# Altium schematic sheet DIP2350.SchDoc
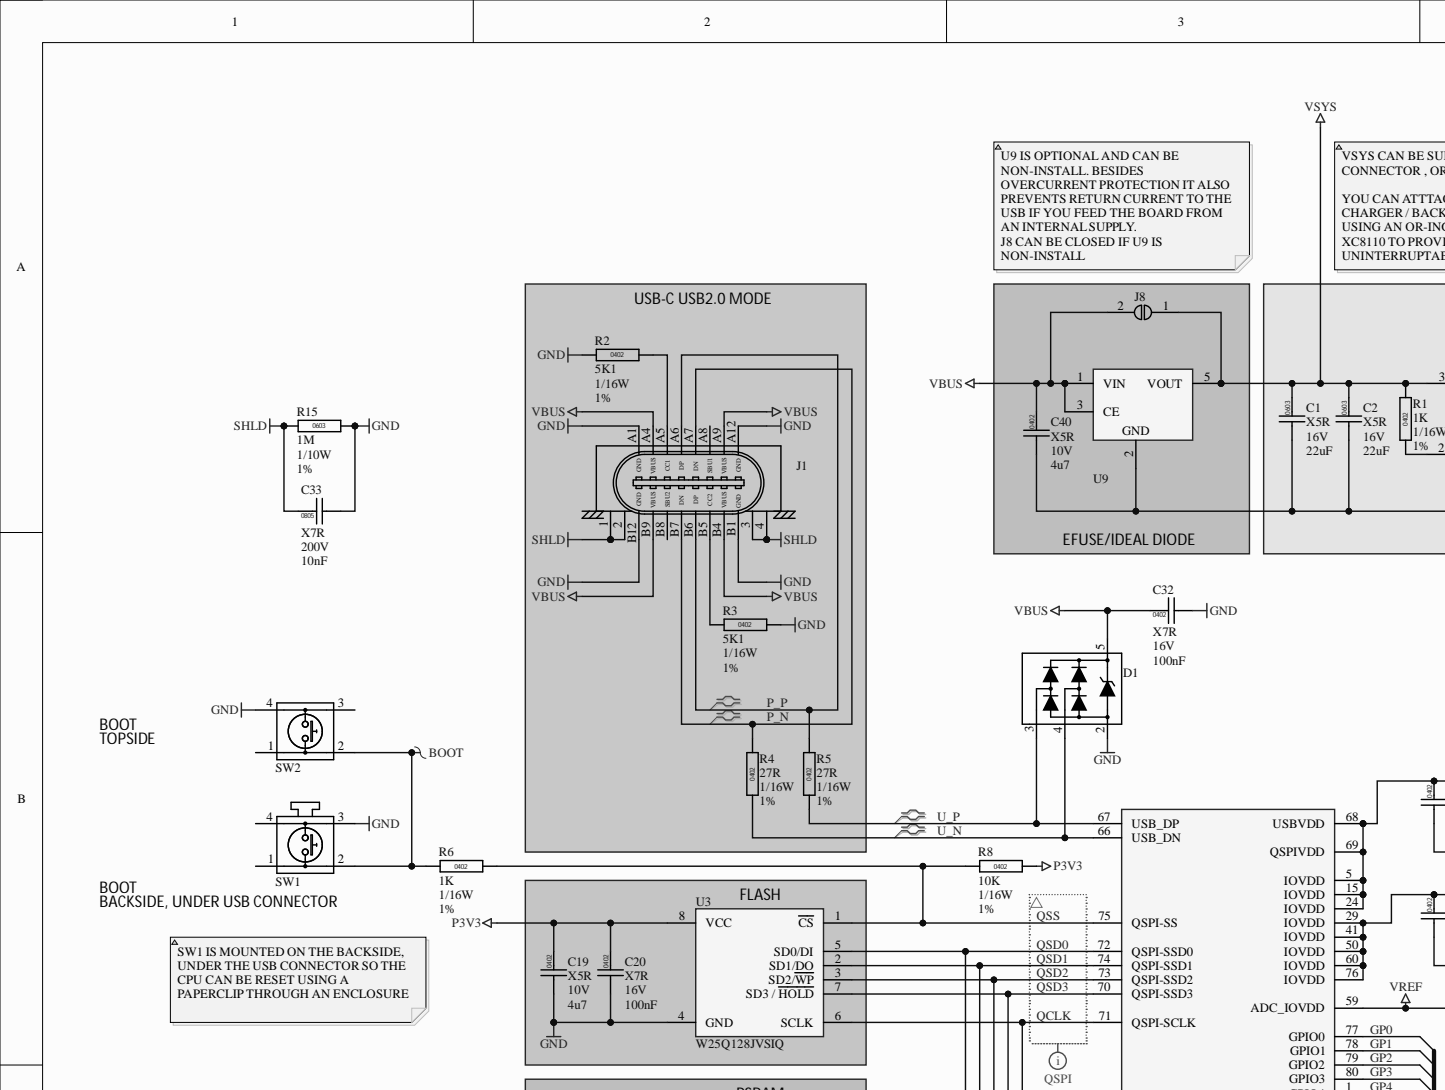
[diagram p0: DIP2350.SchDoc, top left]
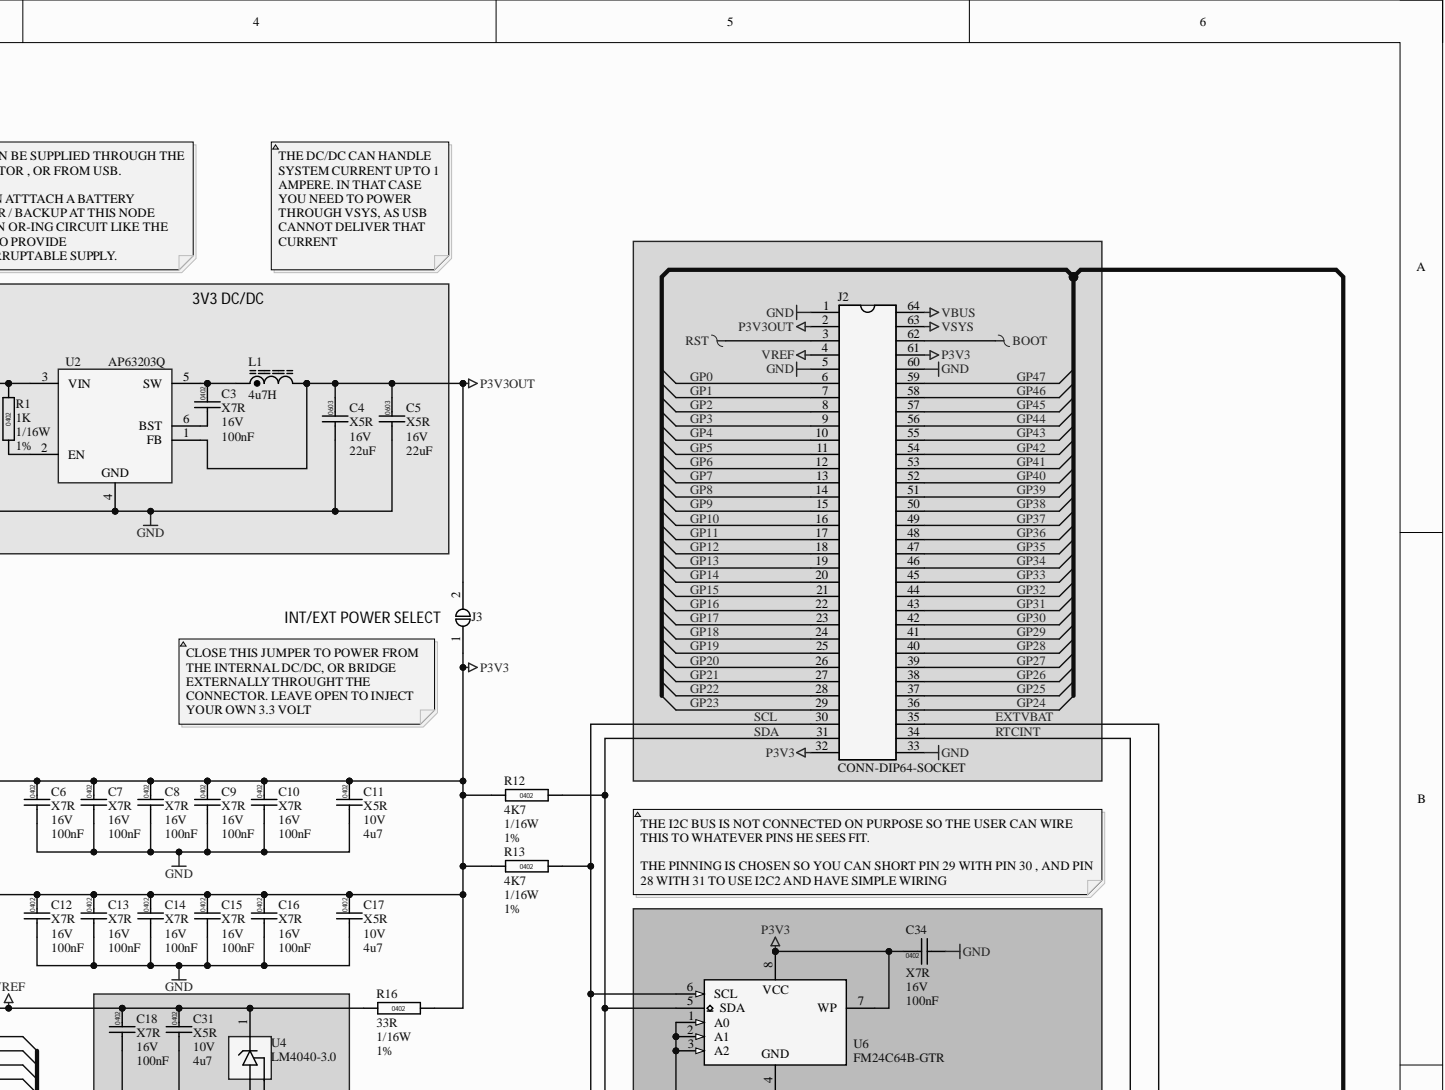
[diagram p1: DIP2350.SchDoc, top right]
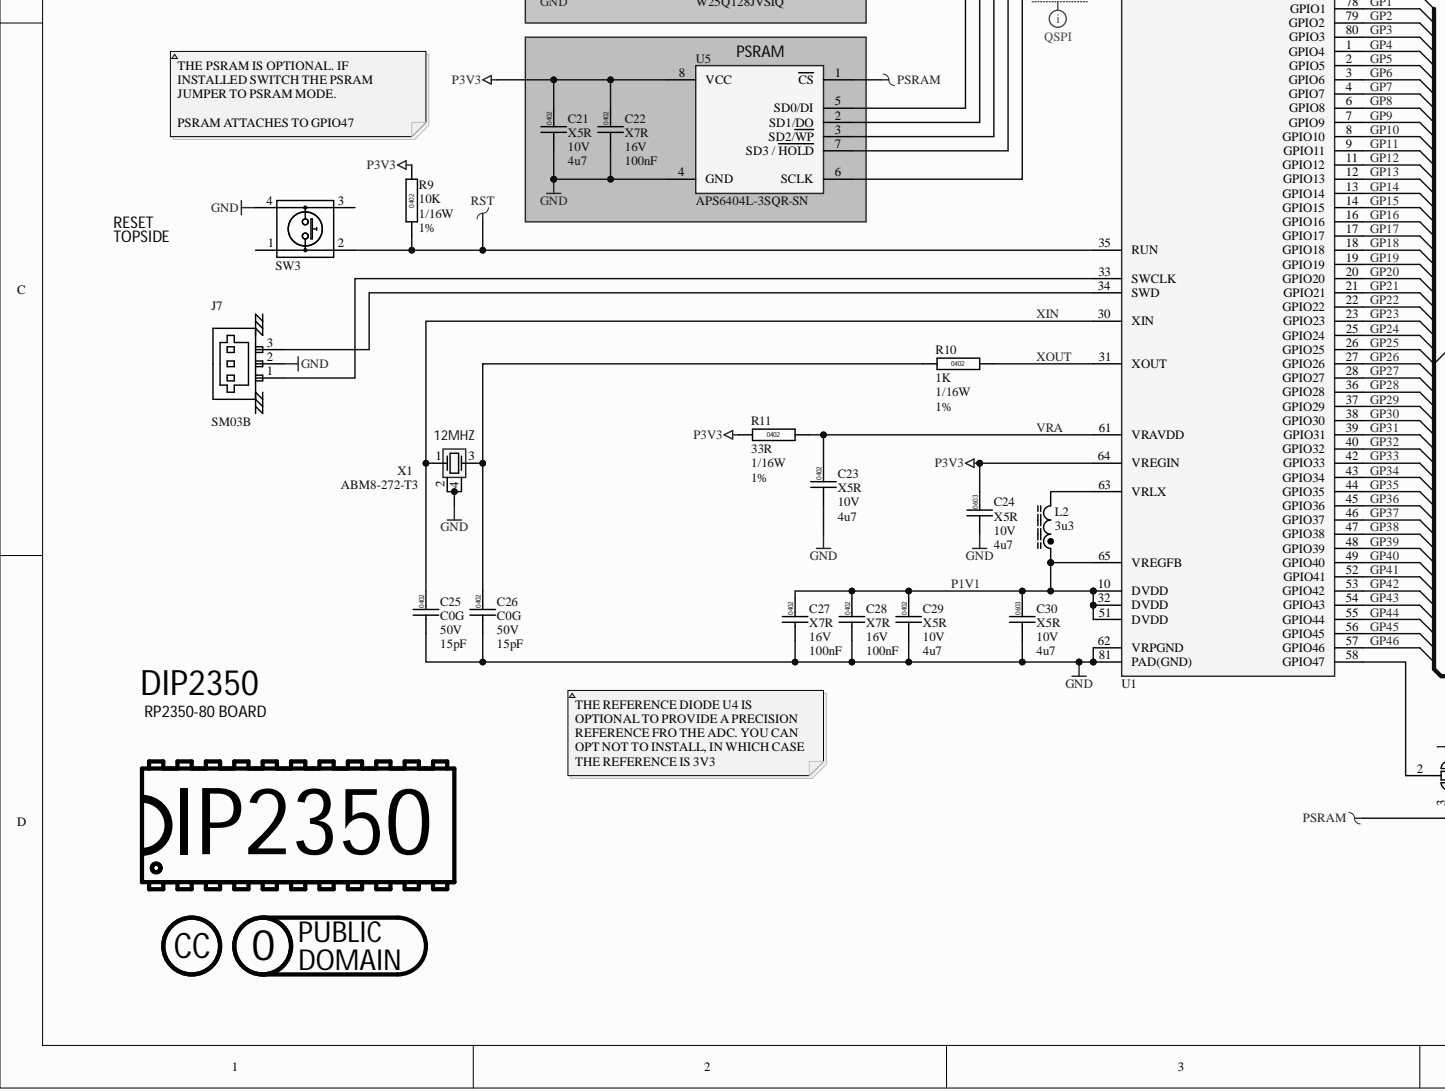
[diagram p2: DIP2350.SchDoc, bottom left]
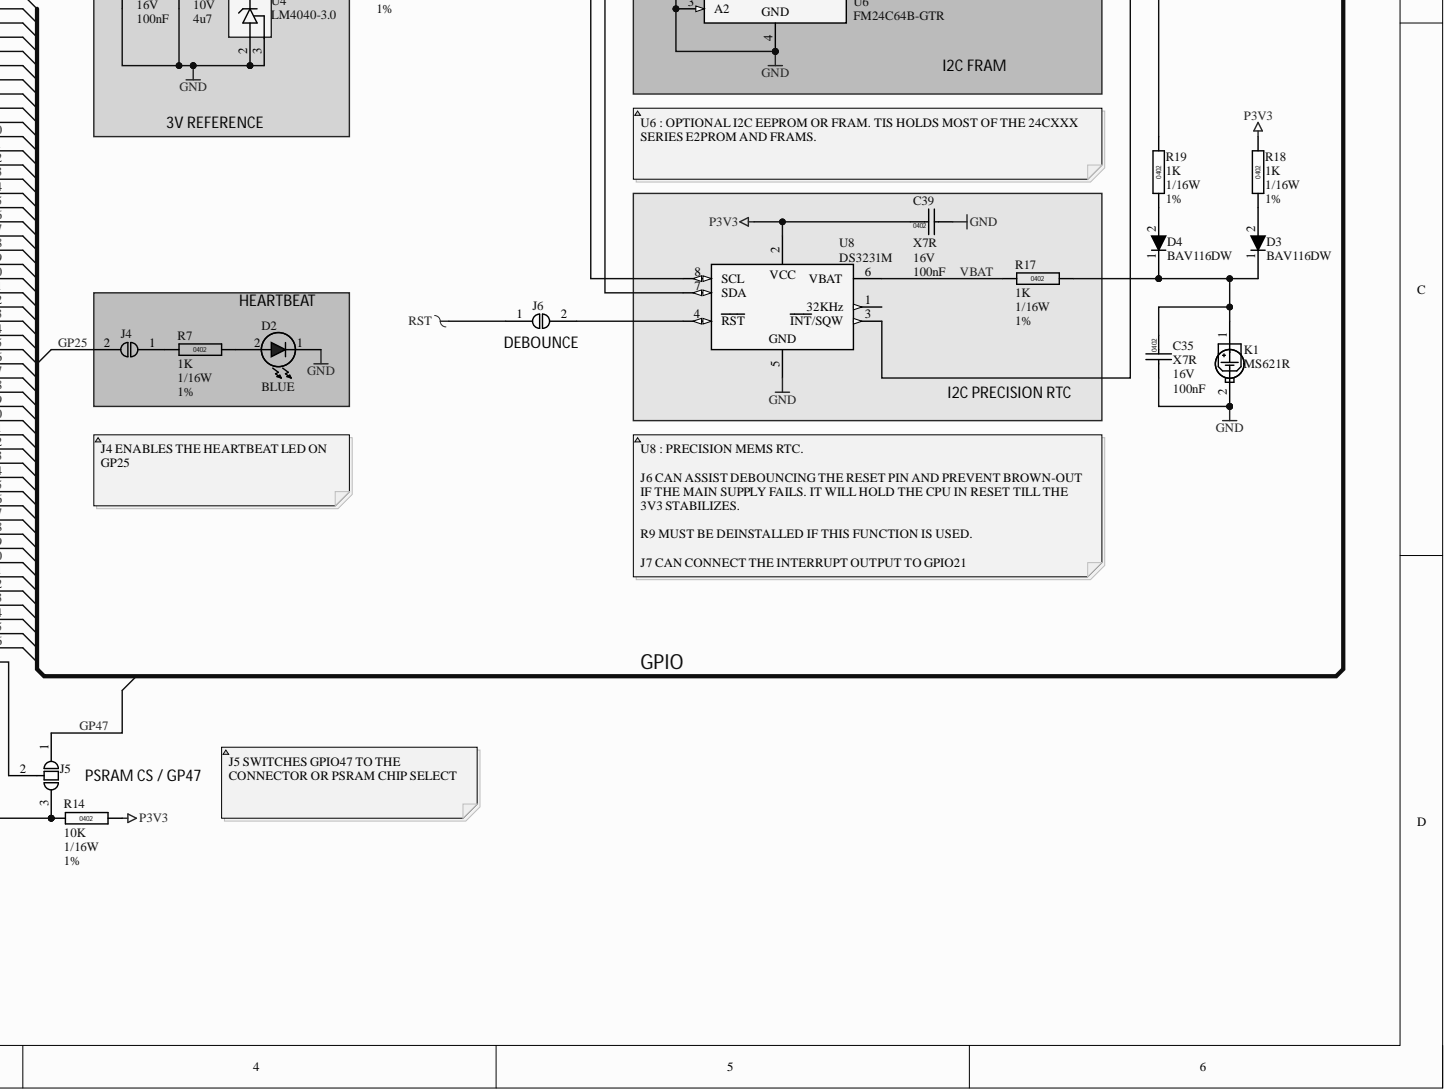
[diagram p3: DIP2350.SchDoc, bottom right]

## Parts
C1  Capacitance: 22uF  Dielectric: X5R  Package / Case: 0603  Voltage: 16V  [CAPC0603]  (hidden comment: CAP0603)
C2  Capacitance: 22uF  Dielectric: X5R  Package / Case: 0603  Voltage: 16V  [CAPC0603]  (hidden comment: CAP0603)
C3  Capacitance: 100nF  Dielectric: X7R  Package / Case: 0402  Voltage: 16V  [CAPC0402]  (hidden comment: CAP0402)
C4  Capacitance: 22uF  Dielectric: X5R  Package / Case: 0603  Voltage: 16V  [CAPC0603]  (hidden comment: CAP0603)
C5  Capacitance: 22uF  Dielectric: X5R  Package / Case: 0603  Voltage: 16V  [CAPC0603]  (hidden comment: CAP0603)
C6  Capacitance: 100nF  Dielectric: X7R  Package / Case: 0402  Voltage: 16V  [CAPC0402]  (hidden comment: CAP0402)
C7  Capacitance: 100nF  Dielectric: X7R  Package / Case: 0402  Voltage: 16V  [CAPC0402]  (hidden comment: CAP0402)
C8  Capacitance: 100nF  Dielectric: X7R  Package / Case: 0402  Voltage: 16V  [CAPC0402]  (hidden comment: CAP0402)
C9  Capacitance: 100nF  Dielectric: X7R  Package / Case: 0402  Voltage: 16V  [CAPC0402]  (hidden comment: CAP0402)
C10  Capacitance: 100nF  Dielectric: X7R  Package / Case: 0402  Voltage: 16V  [CAPC0402]  (hidden comment: CAP0402)
C11  Capacitance: 4u7  Dielectric: X5R  Voltage: 10V  [CAPC0402]  (hidden comment: CAP0402)
C12  Capacitance: 100nF  Dielectric: X7R  Package / Case: 0402  Voltage: 16V  [CAPC0402]  (hidden comment: CAP0402)
C13  Capacitance: 100nF  Dielectric: X7R  Package / Case: 0402  Voltage: 16V  [CAPC0402]  (hidden comment: CAP0402)
C14  Capacitance: 100nF  Dielectric: X7R  Package / Case: 0402  Voltage: 16V  [CAPC0402]  (hidden comment: CAP0402)
C15  Capacitance: 100nF  Dielectric: X7R  Package / Case: 0402  Voltage: 16V  [CAPC0402]  (hidden comment: CAP0402)
C16  Capacitance: 100nF  Dielectric: X7R  Package / Case: 0402  Voltage: 16V  [CAPC0402]  (hidden comment: CAP0402)
C17  Capacitance: 4u7  Dielectric: X5R  Voltage: 10V  [CAPC0402]  (hidden comment: CAP0402)
C18  Capacitance: 100nF  Dielectric: X7R  Package / Case: 0402  Voltage: 16V  [CAPC0402]  (hidden comment: CAP0402)
C19  Capacitance: 4u7  Dielectric: X5R  Voltage: 10V  [CAPC0402]  (hidden comment: CAP0402)
C20  Capacitance: 100nF  Dielectric: X7R  Package / Case: 0402  Voltage: 16V  [CAPC0402]  (hidden comment: CAP0402)
C21  Capacitance: 4u7  Dielectric: X5R  Voltage: 10V  [CAPC0402]  (hidden comment: CAP0402)
C22  Capacitance: 100nF  Dielectric: X7R  Package / Case: 0402  Voltage: 16V  [CAPC0402]  (hidden comment: CAP0402)
C23  Capacitance: 4u7  Dielectric: X5R  Voltage: 10V  [CAPC0402]  (hidden comment: CAP0402)
C24  Capacitance: 4u7  Dielectric: X5R  Package / Case: 0603  Voltage: 10V  [CAPC0603]  (hidden comment: CAP0603)
C25  Capacitance: 15pF  Dielectric: C0G  Package / Case: 0402  Voltage: 50V  [CAPC0402]  (hidden comment: CAP0603)
C26  Capacitance: 15pF  Dielectric: C0G  Package / Case: 0402  Voltage: 50V  [CAPC0402]  (hidden comment: CAP0603)
C27  Capacitance: 100nF  Dielectric: X7R  Package / Case: 0402  Voltage: 16V  [CAPC0402]  (hidden comment: CAP0402)
C28  Capacitance: 100nF  Dielectric: X7R  Package / Case: 0402  Voltage: 16V  [CAPC0402]  (hidden comment: CAP0402)
C29  Capacitance: 4u7  Dielectric: X5R  Voltage: 10V  [CAPC0402]  (hidden comment: CAP0402)
C30  Capacitance: 4u7  Dielectric: X5R  Package / Case: 0603  Voltage: 10V  [CAPC0603]  (hidden comment: CAP0603)
C31  Capacitance: 4u7  Dielectric: X5R  Voltage: 10V  [CAPC0402]  (hidden comment: CAP0402)
C32  Dielectric: X7R  Package / Case: 0402  Voltage: 16V  [CAPC0402]  (hidden comment: CAP0402)
C33  Capacitance: 10nF  Dielectric: X7R  Voltage: 200V  [CAPC0805]  (hidden comment: CAP0805)
C34  Capacitance: 100nF  Dielectric: X7R  Package / Case: 0402  Voltage: 16V  [CAPC0402]  (hidden comment: CAP0402)
C35  Capacitance: 100nF  Dielectric: X7R  Package / Case: 0402  Voltage: 16V  [CAPC0402]  (hidden comment: CAP0402)
C39  Dielectric: X7R  Package / Case: 0402  Voltage: 16V  [CAPC0402]  (hidden comment: CAP0402)
C40  Dielectric: X5R  Voltage: 10V  [CAPC0402]  (hidden comment: CAP0402)
D1  TVS-WURTH-824011  [SOT23-5]  (value not drawn)
D2  BLUE  [LED-0603-BLUE]
D3  BAV116DW  [SOD323]
D4  BAV116DW  [SOD323]
J1  CONN-USBC-USB2-HOR  [CONN-GCT-USB4085]  (value not drawn)
J2  CONN-DIP64-SOCKET  [CONN-DIP64-SOCKET]  (no BOM)
J3  SOLDERPAD-2  [SOLDERPAD-2]  (value not drawn)  (no BOM)
J4  SOLDERPAD-2  [SOLDERPAD-2]  (value not drawn)  (no BOM)
J5  SOLDERPAD-3  [SOLDERPAD-3]  (value not drawn)  (no BOM)
J6  SOLDERPAD-2  [SOLDERPAD-2]  (value not drawn)  (no BOM)
J7  SM03B  [CONN-JST-SM03B-SRSS-TB]
J8  SOLDERPAD-2  [SOLDERPAD-2]  (value not drawn)  (no BOM)
K1  MS621R  [BAT-SEIKO-MS621R]
L1  value 4u7H  [IND-ABRACON-ASPI-3012S-3R9N-T]  (hidden comment: 4.7uH)
L2  value 3u3  [IND-ABRACON-B201610S3R3-101-T]  (hidden comment: AOTA-B201610S3R3-101-T)
LOGO1  LOGO-LICENSE-CC0-PUBLIC  [LOGO-LICENSE-CC0-PUBLIC]  (value not drawn)  (no BOM)
LOGO2  LOGO-LICENSE-CC  [LOGO-LICENSE-CC]  (value not drawn)  (no BOM)
R1  value 1K  Power: 1/16W  Tolerance: 1%  [RESC0402]  (hidden comment: RESC0402)
R2  value 5K1  Power: 1/16W  [RESC0402]  (hidden comment: RESC0402)
R3  value 5K1  Power: 1/16W  Tolerance: 1%  [RESC0402]  (hidden comment: RESC0402)
R4  value 27R  Power: 1/16W  Tolerance: 1%  [RESC0402]  (hidden comment: RESC0402)
R5  value 27R  Power: 1/16W  Tolerance: 1%  [RESC0402]  (hidden comment: RESC0402)
R6  value 1K  Power: 1/16W  Tolerance: 1%  [RESC0402]  (hidden comment: RESC0402)
R7  value 1K  Power: 1/16W  Tolerance: 1%  [RESC0402]  (hidden comment: RESC0402)
R8  value 10K  Power: 1/16W  Tolerance: 1%  [RESC0402]  (hidden comment: RESC0402)
R9  value 10K  Power: 1/16W  Tolerance: 1%  [RESC0402]  (hidden comment: RESC0402)
R10  value 1K  Power: 1/16W  Tolerance: 1%  [RESC0402]  (hidden comment: RESC0402)
R11  value 33R  Power: 1/16W  Tolerance: 1%  [RESC0402]  (hidden comment: RESC0402)
R12  value 4K7  Power: 1/16W  [RESC0402]  (hidden comment: RESC0402)
R13  value 4K7  Power: 1/16W  Tolerance: 1%  [RESC0402]  (hidden comment: RESC0402)
R14  value 10K  Power: 1/16W  Tolerance: 1%  [RESC0402]  (hidden comment: RESC0402)
R15  value 1M  Power: 1/10W  [RESC0603]  (hidden comment: RESC0603)
R16  value 33R  Power: 1/16W  Tolerance: 1%  [RESC0402]  (hidden comment: RESC0402)
R17  value 1K  Power: 1/16W  Tolerance: 1%  [RESC0402]  (hidden comment: RESC0402)
R18  value 1K  Power: 1/16W  Tolerance: 1%  [RESC0402]  (hidden comment: RESC0402)
R19  value 1K  Power: 1/16W  Tolerance: 1%  [RESC0402]  (hidden comment: RESC0402)
SW1  SWITCH-E-SWITCH-TL1016  [SWITCH-ESWITCH-TL1016]  (value not drawn)
SW2  SWITCH-PANASONIC-EVP-AA202K  [SWITCH-PANASONIC-EVP-AA202K]  (value not drawn)
SW3  SWITCH-PANASONIC-EVP-AA202K  [SWITCH-PANASONIC-EVP-AA202K]  (value not drawn)
U1  IC-RP2350-DIP64  [QFN40P1000X1000X90_HS-81N]  (value not drawn)
U2  AP63203Q  [SOT23-6]
U3  W25Q128JVSIQ  [SO127P790-8N]
U4  LM4040-3.0  [SOT23]
U5  APS6404L-3SQR-SN  [SO127P600-8N]
U6  FM24C64B-GTR  [SO127P600-8N]
U8  DS3231M  [SO127P600-8N]
U9  XC8110  [SOT23-5]  (value not drawn)
X1  ABM8-272-T3  [XTAL-ABRACON-ABM8-272-T3]

## Nets
BOOT: J2.62 R6.1 SW1.2 SW2.2
GND: C1.2 C2.2 C4.2 C5.2 C6.2 C7.2 C8.2 C9.2 C10.2 C11.2 C12.2 C13.2 C14.2 C15.2 C16.2 C17.2 C18.2 C19.2 C20.2 C21.2 C22.2 C23.2 C24.2 C25.2 C26.2 C27.2 C28.2 C29.2 C30.2 C31.2 C32.2 C33.2 C34.2 C35.2 C39.2 C40.2 D1.2 D2.1 J1.A1(GND) J1.A12(GND) J1.B1(GND) J1.B12(GND) J2.1 J2.5 J2.33 J2.60 J7.2 K1.2 R2.2 R3.2 R15.2 SW1.3 SW2.4 SW3.4 U1.62(VRPGND) U1.81(PAD(GND)) U2.4 U3.4(GND) U4.2 U4.3 U5.4(GND) U6.1(A0) U6.2(A1) U6.3(A2) U6.4 U8.5 U9.2 X1.2 X1.4
P3V3: C6.1 C7.1 C8.1 C9.1 C10.1 C11.1 C12.1 C13.1 C14.1 C15.1 C16.1 C17.1 C19.1 C20.1 C21.1 C22.1 C24.1 C34.1 C39.1 J2.32 J2.61 J3.1 R8.2 R9.2 R11.1 R12.1 R13.1 R14.2 R16.2 R18.2 U1.5(IOVDD) U1.15(IOVDD) U1.24(IOVDD) U1.29(IOVDD) U1.41(IOVDD) U1.50(IOVDD) U1.60(IOVDD) U1.64(VREGIN) U1.68(USBVDD) U1.69(QSPIVDD) U1.76(IOVDD) U3.8(VCC) U5.8(VCC) U6.7(WP) U6.8 U8.2
P3V3OUT: C4.1 C5.1 J2.2 J3.2 L1.2 U2.1(FB)
PSRAM: J5.3 R14.1 U5.1(CS)
RST: J2.3 J6.1 R9.1 SW3.2 U1.35(RUN)
SHLD: C33.1 J1.1 J1.2 J1.3 J1.4 R15.1
VBUS: C32.1 C40.1 D1.5 J1.A4(VBUS) J1.A9(VBUS) J1.B4(VBUS) J1.B9(VBUS) J2.64 J8.2 U9.1(VIN) U9.3(CE)
VREF: C18.1 C31.1 J2.4 R16.1 U1.59(ADC_IOVDD) U4.1
VSYS: C1.1 C2.1 J2.63 J8.1 R1.2 U2.3(VIN) U9.5(VOUT)
EXTVBAT: J2.35 R19.2
GP0: J2.6 U1.77(GPIO0)
GP1: J2.7 U1.78(GPIO1)
GP2: J2.8 U1.79(GPIO2)
GP3: J2.9 U1.80(GPIO3)
GP4: J2.10 U1.1(GPIO4)
GP5: J2.11 U1.2(GPIO5)
GP6: J2.12 U1.3(GPIO6)
GP7: J2.13 U1.4(GPIO7)
GP8: J2.14 U1.6(GPIO8)
GP9: J2.15 U1.7(GPIO9)
GP10: J2.16 U1.8(GPIO10)
GP11: J2.17 U1.9(GPIO11)
GP12: J2.18 U1.11(GPIO12)
GP13: J2.19 U1.12(GPIO13)
GP14: J2.20 U1.13(GPIO14)
GP15: J2.21 U1.14(GPIO15)
GP16: J2.22 U1.16(GPIO16)
GP17: J2.23 U1.17(GPIO17)
GP18: J2.24 U1.18(GPIO18)
GP19: J2.25 U1.19(GPIO19)
GP20: J2.26 U1.20(GPIO20)
GP21: J2.27 U1.21(GPIO21)
GP22: J2.28 U1.22(GPIO22)
GP23: J2.29 U1.23(GPIO23)
GP24: J2.36 U1.25(GPIO24)
GP25: J2.37 J4.2 U1.26(GPIO25)
GP26: J2.38 U1.27(GPIO26)
GP27: J2.39 U1.28(GPIO27)
GP28: J2.40 U1.36(GPIO28)
GP29: J2.41 U1.37(GPIO29)
GP30: J2.42 U1.38(GPIO30)
GP31: J2.43 U1.39(GPIO31)
GP32: J2.44 U1.40(GPIO32)
GP33: J2.45 U1.42(GPIO33)
GP34: J2.46 U1.43(GPIO34)
GP35: J2.47 U1.44(GPIO35)
GP36: J2.48 U1.45(GPIO36)
GP37: J2.49 U1.46(GPIO37)
GP38: J2.50 U1.47(GPIO38)
GP39: J2.51 U1.48(GPIO39)
GP40: J2.52 U1.49(GPIO40)
GP41: J2.53 U1.52(GPIO41)
GP42: J2.54 U1.53(GPIO42)
GP43: J2.55 U1.54(GPIO43)
GP44: J2.56 U1.55(GPIO44)
GP45: J2.57 U1.56(GPIO45)
GP46: J2.58 U1.57(GPIO46)
GP47: J2.59 J5.1
P1V1: C27.1 C28.1 C29.1 C30.1 L2.1 U1.10(DVDD) U1.32(DVDD) U1.51(DVDD) U1.65(VREGFB)
P_N: J1.A7(DN) J1.B7(DN) R4.1
P_P: J1.A6(DP) J1.B6(DP) R5.1
QCLK: U1.71(QSPI-SCLK) U3.6(SCLK) U5.6(SCLK)
QSD0: U1.72(QSPI-SSD0) U3.5(SD0/DI) U5.5(SD0/DI)
QSD1: U1.74(QSPI-SSD1) U3.2(SD1/DO) U5.2(SD1/DO)
QSD2: U1.73(QSPI-SSD2) U3.3(SD2/WP) U5.3(SD2/WP)
QSD3: U1.70(QSPI-SSD3) U3.7(SD3_/_HOLD) U5.7(SD3_/_HOLD)
QSS: R6.2 R8.1 U1.75(QSPI-SS) U3.1(CS)
RTCINT: J2.34 U8.3(INT/SQW)
SCL: J2.30 R13.2 U6.6(SCL) U8.8(SCL)
SDA: J2.31 R12.2 U6.5(SDA) U8.7(SDA)
U_N: D1.4 R4.2 U1.66(USB_DN)
U_P: D1.3 R5.2 U1.67(USB_DP)
VBAT: R17.1 U8.6(VBAT)
VRA: C23.1 R11.2 U1.61(VRAVDD)
XIN: C25.1 U1.30(XIN) X1.1
XOUT: R10.2 U1.31(XOUT)
NetC3_1: C3.1 L1.1 U2.5(SW)
NetC3_2: C3.2 U2.6(BST)
NetC26_1: C26.1 R10.1 X1.3
NetC35_1: C35.1 D3.1 D4.1 K1.1 R17.2
NetD2_2: D2.2 R7.2
NetD3_2: D3.2 R18.1
NetD4_2: D4.2 R19.1
NetJ1_A5: J1.A5(CC1) R2.1
NetJ1_B5: J1.B5(CC2) R3.1
NetJ4_1: J4.1 R7.1
NetJ5_2: J5.2 U1.58(GPIO47)
NetJ6_2: J6.2 U8.4(RST)
NetJ7_1: J7.1 U1.33(SWCLK)
NetJ7_3: J7.3 U1.34(SWD)
NetL2_2: L2.2 U1.63(VRLX)
NetR1_1: R1.1 U2.2(EN)

Not connected: J1 A8(SBU1) B8(SBU2); SW1 1 4; SW2 1 3; SW3 1 3; U8 1(32KHz)

## Library data of the parts
C1 C2 C4 C5 = CAPC-0603-22uF-16V-X5R: CAPACITOR,CERAMIC,22uF,16V,X5R,0603; Component Kind: Standard; LCSC: C2762594; Library Name: CAPACITORS.SchLib; Supplier 1: Digikey; Supplier Part Number 1: 1276-7076-1-ND
C3 C6-C10 C12-C16 C18 C20 C22 C27 C28 C32 C34 C35 C39 = CAPC-0402-100nF-16V-X7R: CAPACITOR,CERAMIC,100nF,16V,X7R,0402; Component Kind: Standard; LCSC: C60474; Library Name: CAPACITORS.SchLib; Supplier 1: Digikey; Supplier Part Number 1: 1292-1630-1-ND
  C32 C39: Capacitance: 100nF
C11 C17 C19 C21 C23 C29 C31 C40 = CAPC-0402-4u7-10V-X5R: CAPACITOR,CERAMIC,4u7,10V,X5R,0402; Component Kind: Standard; LCSC: C1705; Library Name: CAPACITORS.SchLib; Package / Case: 0603; Supplier 1: Digikey; Supplier Part Number 1: 1276-1482-1-ND
  C40: Capacitance: 4u7
C24 C30 = CAPC-0603-4u7-10V-X5R: CAPACITOR,CERAMIC,4u7,10V,X5R,0603; Component Kind: Standard; LCSC: C1705; Library Name: CAPACITORS.SchLib; Supplier 1: Arrow Electronics; Supplier Part Number 1: GRM188Z71A475KE15J
C25 C26 = CAPC-0402-15PF-50V-C0G: CAPACITOR,CERAMIC,15pF,50V,C0G,0402; Component Kind: Standard; LCSC: C3906764; Library Name: CAPACITORS.SchLib; Supplier 1: Digikey; Supplier Part Number 1: 311-3780-1-ND
C33 = CAPC-0805-10nF-200V-ESD: CAPACITOR,CERAMIC,10nF,200V,X7R,0805 (2012),ESD; Component Kind: Standard; LCSC: C36911368; Library Name: CAPACITORS.SchLib; Package / Case: 0805 (2012 metric); Supplier 1: Mouser; Supplier Part Number 1: 80-C0805C103K2RECAUT
D1 = TVS-WURTH-824011: DIODE,TVS,DUAL,UNIDIRECTIONAL,USB; Component Kind: Standard; LCSC: C22358704; Library Name: DIODES.SchLib; Supplier 1: Digikey; Supplier Part Number 1: 732-4465-1-ND
D2 = LED-WURTH-150060BS75000: LED,BLUE,0603; Component Kind: Standard; LCSC: C965807; Library Name: LED.SchLib; Supplier 1: Digikey; Supplier Part Number 1: 732-4966-1-ND
D3 D4 = DIODE-DIODES-BAV116WS: DIODE,75V,215mA,SOD323,LOW LEAKAGE; Component Kind: Standard; LCSC: C459842; Library Name: DIODES.SchLib; Supplier 1: Arrow Electronics; Supplier Part Number 1: BAV116WS-7
J1 = CONN-USBC-USB2-HOR: CONN,USB-C,16P,RA,TH,8.94X9.17; Component Kind: Standard; LCSC: C36936564; Library Name: CONNECTORS.SchLib; Supplier 1: Digikey; Supplier Part Number 1: 2073-USB4085-GF-ACT-ND
J7 = CONN-JST-SM03B-SRSS-TB: CONN,1X3,1MM,RA,SMD,JST,SM03B; Component Kind: Standard; LCSC: C160403; Library Name: CONNECTORS.SchLib; Supplier 1: Future Electronics; Supplier Part Number 1: 4483905
K1 = BAT-SEIKO-MS621R: BAT,3V,SMD,SEIKO MS621R; Component Kind: Standard; Supplier 1: Digikey; Supplier Part Number 1: 728-MS621RII27ECT-ND; Library Name: BATTERIES.SchLib
L1 = IND-TAIYO-NRH3012T4R7MN: IND,4.7uH,1.06A,3X3, NRH3012T4R7MN; Component Kind: Standard; LCSC: C5159666; Library Name: INDUCTORS.SchLib; Supplier 1: Digikey; Supplier Part Number 1: 587-2591-1-ND
L2 = IND-ABRACON-AOTA-B201610S3R3-101-T: IND,3U3,RP2350, ABRACON AOTA-B201610S3R3-101-T; Component Kind: Standard; LCSC: C42411119; Library Name: INDUCTORS.SchLib; Supplier 1: Mouser; Supplier Part Number 1: 815-AB201610S3R3101T
R1 R6 R7 R10 R17-R19 = RESC-0402-1K-1%-1/10W: RESISTOR,1K,1%,63mW,0402 (1005); Component Kind: Standard; LCSC: C106235; Library Name: RESISTORS.SchLib; Supplier 1: Future Electronics; Supplier Part Number 1: 7825607
R2 R3 = RESC-0402-5K1-1%-1/10W: RESISTOR,5K1,1%,63mW,0402 (1005); Component Kind: Standard; LCSC: C105872; Library Name: RESISTORS.SchLib; Supplier 1: Future Electronics; Supplier Part Number 1: 6053649
  R2: Tolerance: 1%
R4 R5 = RESC-0402-27R-1%-1/10W: RESISTOR,27R,1%,63mW,0402 (1005); Component Kind: Standard; LCSC: C138021; Library Name: RESISTORS.SchLib; Supplier 1: Future Electronics; Supplier Part Number 1: 2577120
R8 R9 R14 = RESC-0402-10K-1%-1/10W: RESISTOR,10K,1%,63mW,0402 (1005); Component Kind: Standard; LCSC: C60490; Library Name: RESISTORS.SchLib; Supplier 1: Future Electronics; Supplier Part Number 1: 3388022
R11 R16 = RESC-0402-33R-1%-1/10W: RESISTOR,33R,1%,63mW,0402 (1005); Component Kind: Standard; LCSC: C138002; Library Name: RESISTORS.SchLib; Supplier 1: Future Electronics; Supplier Part Number 1: 8177883
R12 R13 = RESC-0402-4K7-1%-1/10W: RESISTOR,4K7,1%,63mW,0402 (1005); Component Kind: Standard; LCSC: C105871; Library Name: RESISTORS.SchLib; Supplier 1: Future Electronics; Supplier Part Number 1: 3795218
  R12: Tolerance: 1%
R15 = RESC-0603-1M-1%-1/10W: RESISTOR,1M,1%,100mW,0603 (1608); Component Kind: Standard; LCSC: C105578; Library Name: RESISTORS.SchLib; Supplier 1: Future Electronics; Supplier 2: Mouser; Supplier 3: Avnet; Supplier Part Number 1: 2912863; Supplier Part Number 2: 667-ERJ-3EKF1004V; Supplier Part Number 3: 6-2176339-1; Tolerance: 1%
SW1 = SWITCH-E-SWITCH-TL1016: SW,SMD,TACT,4PIN,2.9X3.5,4PIN,SIDE ACTUATOR; Component Kind: Standard; LCSC: C483790; Library Name: SWITCH.SchLib; Supplier 1: Digikey; Supplier Part Number 1: 141-TL1016AAF160QGCT-ND
SW2 SW3 = SWITCH-PANASONIC-EVP-AA202K: SW,SMD,TACT,4PIN,2.9X3.5,4PIN; Component Kind: Standard; LCSC: C483888; Library Name: SWITCH.SchLib; Supplier 1: Mouser; Supplier Part Number 1: 667-EVP-AA202K
U1 = IC-RP2350-DIP64: IC,CPU,RP2350B,QFN80; Component Kind: Standard; LCSC: C42415655; Library Name: IC-CPU.SchLib
U2 = IC-DIODES-AP63203Q: IC,PMIC,STEP-DOWN, 2A 3.3V; Component Kind: Standard; LCSC: C780769; Library Name: IC-REG.SchLib; Supplier 1: Digikey; Supplier Part Number 1: 31-AP63203QWU-7CT-ND
U3 = IC-WINBOND-W25Q128JVSIQ: IC,FLASH,QSPI,16Mx8; Component Kind: Standard; LCSC: C97521; Library Name: IC-SPI.SchLib; Supplier 1: Digikey; Supplier Part Number 1: W25Q128JVSIQCT-ND
U4 = IC-TI-LM4040-3: IC,VOLTAGE REFERENCE,3.0V; Component Kind: Standard; LCSC: C460608; Library Name: IC-REG.SchLib; Supplier 1: Mouser; Supplier Part Number 1: 926-LM4040AIM330NOPB
U5 = IC-APMEMORY-APS6404L-3SQR-SN: IC,PSRAM,QSPI,8Mx8,SO8; Component Kind: Standard; LCSC: C5333729; Library Name: IC-SPI.SchLib; Supplier 1: Mouser; Supplier Part Number 1: 878-APS6404L-3SQR-SN
U6 = IC-INFINEON-FM24C64B-GTR: IC,FRAM,I2C, 64KBIT; Component Kind: Standard; LCSC: C914923; Library Name: IC-I2C.SchLib; Supplier 1: Arrow Electronics; Supplier Part Number 1: FM24C64B-GTR
U8 = IC-ANALOG-DS3231M: IC,RTC,I2C,DS3231M; Component Kind: Standard; LCSC: C107410; Library Name: IC-I2C.SchLib; Supplier 1: Mouser; Supplier Part Number 1: 700-DS3231MZ+TRL
U9 = IC-TOREX-XC8110: IC,PMIC,IDEAL DIODE ORING CONTROLLER; Component Kind: Standard; LCSC: C3235547; Library Name: IC-REG.SchLib; Supplier 1: Digikey; Supplier Part Number 1: 893-XC8110AA01MR-GCT-ND
X1 = XTAL-ABRACON-ABM8-272-T3: XTAL,12MHZ,RP2350; Component Kind: Standard; LCSC: C20625731; Library Name: CRYSTALS.SchLib; Supplier 1: Mouser; Supplier Part Number 1: 815-ABM8-272-T3
J2 = CONN-DIP64-SOCKET: Library Name: CONNECTORS.SchLib
LOGO1 = LOGO-LICENSE-CC0-PUBLIC: Library Name: LOGO.SCHLIB
LOGO2 = LOGO-LICENSE-CC: Library Name: LOGO.SCHLIB
J3 J4 J6 J8 = SOLDERPAD-2: Library Name: BOARDFEATURES.SchLib
J5 = SOLDERPAD-3: Library Name: BOARDFEATURES.SchLib
